# Altium schematic sheet 03-MCU.SchDoc
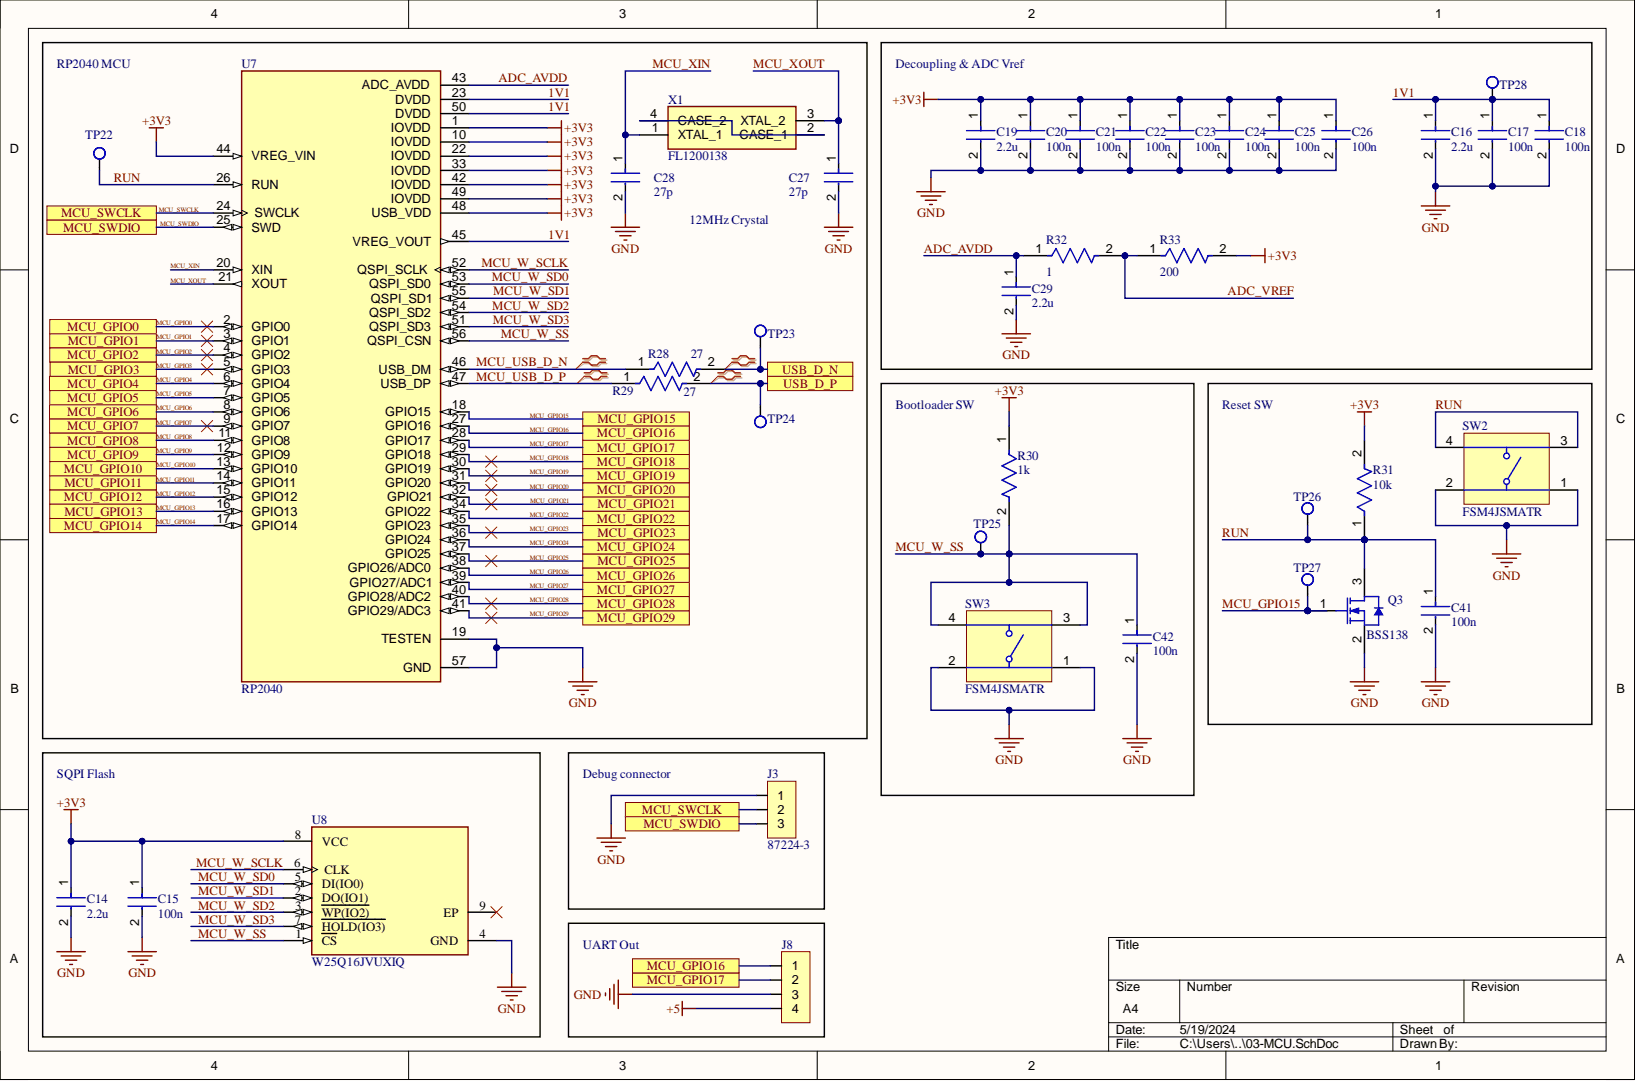
[diagram p0: 03-MCU.SchDoc]

## Parts
C14  2.2u  [FP-CC0805-0_85-MFG]
C15  100n  [FP-CC0805-0_85-MFG]
C16  2.2u  [FP-CC0805-0_85-MFG]
C17  100n  [FP-CC0805-0_85-MFG]
C18  100n  [FP-CC0805-0_85-MFG]
C19  2.2u  [FP-CC0805-0_85-MFG]
C20  100n  [FP-CC0805-0_85-MFG]
C21  100n  [FP-CC0805-0_85-MFG]
C22  100n  [FP-CC0805-0_85-MFG]
C23  100n  [FP-CC0805-0_85-MFG]
C24  100n  [FP-CC0805-0_85-MFG]
C25  100n  [FP-CC0805-0_85-MFG]
C26  100n  [FP-CC0805-0_85-MFG]
C27  27p  [FP-CC0805-0_85-MFG]
C28  27p  [FP-CC0805-0_85-MFG]
C29  2.2u  [FP-CC0805-0_85-MFG]
C41  100n  [FP-CC0805-0_85-MFG]
C42  100n  [FP-CC0805-0_85-MFG]
J3  87224-3  [FP-87224-3-MFG]
J8  TSW-104-08-L-S  [SMTC-TSW-104-08-X-S]  (value not drawn)
Q3  BSS138  [FAIR-SOT23-3_V]
R28  27  [FP-RT0805-MFG]
R29  27  [FP-RT0805-MFG]
R30  1k  [FP-RT0805-MFG]
R31  10k  [FP-RT0805-MFG]
R32  1  [FP-RT0805-MFG]
R33  200  [FP-RT0805-MFG]
SW2  FSM4JSMATR  [TECO-FSM4JSMATR_V]
SW3  FSM4JSMATR  [TECO-FSM4JSMATR_V]
TP22  Test point  [Test point-Footprint-1]  (value not drawn)
TP23  Test point  [Test point-Footprint-1]  (value not drawn)
TP24  Test point  [Test point-Footprint-1]  (value not drawn)
TP25  Test point  [Test point-Footprint-1]  (value not drawn)
TP26  Test point  [Test point-Footprint-1]  (value not drawn)
TP27  Test point  [Test point-Footprint-1]  (value not drawn)
TP28  Test point  [Test point-Footprint-1]  (value not drawn)
U7  RP2040  [QFN40P700X700X90-57N]
U8  W25Q16JVUXIQ  [FP-UX-MFG]
X1  FL1200138  [FL1200138]

## Ports
MCU_GPIO0, MCU_GPIO1, MCU_GPIO2, MCU_GPIO3, MCU_GPIO4, MCU_GPIO5, MCU_GPIO6, MCU_GPIO7, MCU_GPIO8, MCU_GPIO9, MCU_GPIO10, MCU_GPIO11, MCU_GPIO12, MCU_GPIO13, MCU_GPIO14, MCU_GPIO15, MCU_GPIO16, MCU_GPIO17, MCU_GPIO18, MCU_GPIO19, MCU_GPIO20, MCU_GPIO21, MCU_GPIO22, MCU_GPIO23, MCU_GPIO24, MCU_GPIO25, MCU_GPIO26, MCU_GPIO27, MCU_GPIO28, MCU_GPIO29, MCU_SWCLK, MCU_SWDIO, USB_D_N, USB_D_P

## Nets
+3V3: C14.1 C15.1 C19.1 C20.1 C21.1 C22.1 C23.1 C24.1 C25.1 C26.1 R30.1 R31.2 R33.2 U7.1(IOVDD) U7.10(IOVDD) U7.22(IOVDD) U7.33(IOVDD) U7.42(IOVDD) U7.44(VREG_VIN) U7.48(USB_VDD) U7.49(IOVDD) U8.8(VCC)
+5: J8.4
GND: C14.2 C15.2 C16.2 C17.2 C18.2 C19.2 C20.2 C21.2 C22.2 C23.2 C24.2 C25.2 C26.2 C27.2 C28.2 C29.2 C41.2 C42.2 J3.1 J8.3 Q3.2 SW2.1 SW2.2 SW3.1 SW3.2 U7.19(TESTEN) U7.57(GND) U8.4(GND)
1V1: C16.1 C17.1 C18.1 TP28.1 U7.23(DVDD) U7.45(VREG_VOUT) U7.50(DVDD)
ADC_AVDD: C29.1 R32.1 U7.43(ADC_AVDD)
ADC_VREF: R32.2 R33.1
MCU_GPIO0: U7.2(GPIO0) ; port MCU_GPIO0
MCU_GPIO1: U7.3(GPIO1) ; port MCU_GPIO1
MCU_GPIO2: U7.4(GPIO2) ; port MCU_GPIO2
MCU_GPIO3: U7.5(GPIO3) ; port MCU_GPIO3
MCU_GPIO4: U7.6(GPIO4) ; port MCU_GPIO4
MCU_GPIO5: U7.7(GPIO5) ; port MCU_GPIO5
MCU_GPIO6: U7.8(GPIO6) ; port MCU_GPIO6
MCU_GPIO7: U7.9(GPIO7) ; port MCU_GPIO7
MCU_GPIO8: U7.11(GPIO8) ; port MCU_GPIO8
MCU_GPIO9: U7.12(GPIO9) ; port MCU_GPIO9
MCU_GPIO10: U7.13(GPIO10) ; port MCU_GPIO10
MCU_GPIO11: U7.14(GPIO11) ; port MCU_GPIO11
MCU_GPIO12: U7.15(GPIO12) ; port MCU_GPIO12
MCU_GPIO13: U7.16(GPIO13) ; port MCU_GPIO13
MCU_GPIO14: U7.17(GPIO14) ; port MCU_GPIO14
MCU_GPIO15: Q3.1 TP27.1 U7.18(GPIO15) ; port MCU_GPIO15
MCU_GPIO16: J8.1 U7.27(GPIO16) ; port MCU_GPIO16
MCU_GPIO17: J8.2 U7.28(GPIO17) ; port MCU_GPIO17
MCU_GPIO18: U7.29(GPIO18) ; port MCU_GPIO18
MCU_GPIO19: U7.30(GPIO19) ; port MCU_GPIO19
MCU_GPIO20: U7.31(GPIO20) ; port MCU_GPIO20
MCU_GPIO21: U7.32(GPIO21) ; port MCU_GPIO21
MCU_GPIO22: U7.34(GPIO22) ; port MCU_GPIO22
MCU_GPIO23: U7.35(GPIO23) ; port MCU_GPIO23
MCU_GPIO24: U7.36(GPIO24) ; port MCU_GPIO24
MCU_GPIO25: U7.37(GPIO25) ; port MCU_GPIO25
MCU_GPIO26: U7.38(GPIO26/ADC0) ; port MCU_GPIO26
MCU_GPIO27: U7.39(GPIO27/ADC1) ; port MCU_GPIO27
MCU_GPIO28: U7.40(GPIO28/ADC2) ; port MCU_GPIO28
MCU_GPIO29: U7.41(GPIO29/ADC3) ; port MCU_GPIO29
MCU_SWCLK: J3.2 U7.24(SWCLK) ; port MCU_SWCLK
MCU_SWDIO: J3.3 U7.25(SWD) ; port MCU_SWDIO
MCU_USB_D_N: R28.1 U7.46(USB_DM)
MCU_USB_D_P: R29.1 U7.47(USB_DP)
MCU_W_SCLK: U7.52(QSPI_SCLK) U8.6(CLK)
MCU_W_SD0: U7.53(QSPI_SD0) U8.5(DI(IO0))
MCU_W_SD1: U7.55(QSPI_SD1) U8.2(DO(IO1))
MCU_W_SD2: U7.54(QSPI_SD2) U8.3(WP(IO2))
MCU_W_SD3: U7.51(QSPI_SD3) U8.7(HOLD(IO3))
MCU_W_SS: C42.1 R30.2 SW3.3 SW3.4 TP25.1 U7.56(QSPI_CSN) U8.1(CS)
MCU_XIN: C28.1 U7.20(XIN) X1.1(XTAL_1)
MCU_XOUT: C27.1 U7.21(XOUT) X1.3(XTAL_2)
RUN: C41.1 Q3.3 R31.1 SW2.3 SW2.4 TP22.1 TP26.1 U7.26(RUN)
USB_D_N: R28.2 TP23.1 ; port USB_D_N
USB_D_P: R29.2 TP24.1 ; port USB_D_P
NetX1_2: X1.2(CASE_1) X1.4(CASE_2)

Not connected: U8 9(EP)

## Library data of the parts
C14 C15 C17 C18 C20-C29 C41 C42 = CMP-1036-04410-2: CAP CER 0.1UF 25V X7R 0805; Manufacturer: Yageo; Manufacturer Part Number: CC0805KRX7R8BB104; Capacitance: 100 nF; Material: Ceramic; Tolerance: 0.1; Value: 100 nF; Automotive: No; Category: Cap; Component Kind: Standard; ComponentLink2Description: Datasheet; ComponentLink2URL: http://www.yageo.com/documents/recent/UPY-GPHC_Y5V_6.3V-to-50V_8.pdf; Device Class L1: Passive Components; Device Class L2: Capacitors; Device Class L3: Ceramic Capacitors; Digikey Description: CAP CER 0.1UF 25V X7R 0805; Footprint Url: http://www.yageo.com/exep/pages/download/literatures/UPY-C_GEN_24.pdf; Height: 0.95mm; Ipc Land Pattern Name: CAPC200125X85; Lead Free: Yes; Library Name: Altium Content Vault; Mouser Description: Multilayer Ceramic Capacitors MLCC - SMD/SMT 100nF 25V X7R 10%; Octopart: https://octopart.com/cc0805krx7r8bb104-yageo-39467766; Package: CC0805-0.85; RoHS: TRUE; Temperature: -30°C to +85°C; Temperature Characteristic: X7R; Temperature Range High: +85°C; Temperature Range Low: -30°C; Voltage Rating: 25 V
  C14 C29: LCSC_Part_#: C377773
  C15 C17 C18 C20-C26 C41 C42: LCSC_Part_#: C49678
  C27 C28: LCSC_Part_#: C1808
C16 C19 = CMP-1036-04410-2: CAP CER 2.2UF 25V X7R 0805; Manufacturer: Yageo; Manufacturer Part Number: CC0805KRX7R8BB104; Capacitance: 100 nF; Material: Ceramic; Tolerance: 0.1; Value: 100 nF; Automotive: No; Category: Cap; Component Kind: Standard; ComponentLink2Description: Datasheet; ComponentLink2URL: http://www.yageo.com/documents/recent/UPY-GPHC_Y5V_6.3V-to-50V_8.pdf; Device Class L1: Passive Components; Device Class L2: Capacitors; Device Class L3: Ceramic Capacitors; Digikey Description: CAP CER 0.1UF 25V X7R 0805; Footprint Url: http://www.yageo.com/exep/pages/download/literatures/UPY-C_GEN_24.pdf; Height: 0.95mm; Ipc Land Pattern Name: CAPC200125X85; LCSC_Part_#: C377773; Lead Free: Yes; Library Name: Altium Content Vault; Mouser Description: Multilayer Ceramic Capacitors MLCC - SMD/SMT 100nF 25V X7R 10%; Octopart: https://octopart.com/cc0805krx7r8bb104-yageo-39467766; Package: CC0805-0.85; RoHS: TRUE; Temperature: -30°C to +85°C; Temperature Characteristic: X7R; Temperature Range High: +85°C; Temperature Range Low: -30°C; Voltage Rating: 25 V
J3 = CMP-002-00074-1: Board Connector, 3 Contact(s), 1 Row(s), Male, Straight, 0.1 inch Pitch, Solder Terminal, Black Insulator, Receptacle; Component Kind: Standard; Connector Type: BOARD CONNECTOR; Max Operating Temperature: 105°C; Min Operating Temperature: -65°C; Mounting Technology: Board; RoHS Compliant: Yes; Library Name: Clement Verda
J8 = CMP-002-00076-1: Board Connector, 4 Contact(s), 1 Row(s), Male, Straight, Solder Terminal; Component Kind: Standard; Connector Type: BOARD CONNECTOR; Mounting Technology: Board; RoHS Compliant: Yes; Library Name: Clement Verda
Q3 = CMP-013-00021-4: N-Channel Logic Level Enhancement Mode Field Effect Transistor, 220 mA, 50 V, -55 to 150 degC, 3-Pin SOT-23, RoHS, Tape and Reel; Manufacturer: Fairchild; Elements: 1; Input Capacitance: 27pF; Nominal Dual Supply Voltage: 50V; Nominal Vgs: 1.3V; Package Version: Rev. 12, 03/2016; Power: 360mW; Rds On Max: 3.5Ohm; Resistance: 0.7Ohm; Typical Rise Time: 9ns; Case/Package: TO-236-3; Component Kind: Standard; Datasheet URL: https://www.fairchildsemi.com/datasheets/BS/BSS138.pdf; Datasheet Version: Rev. C, 10/2005; LCSC_Part_#: C112239; Max Operating Temperature: 150°C; Min Operating Temperature: -55°C; Mounting Technology: SM; Package Description: 3-Pin Small Outline Transistor, Body 2.92 x 1.325 mm, Pitch 0.95 mm; Package Quantity: 1; Package Reference: SOT23-3; Packaging: TapeandReel; Pins: 3; RoHS Compliant: True; Library Name: Clement Verda
R28 R29 R31-R33 = CMP-03412-005354-1: RES SMD 4.7K OHM 0.5% 1/8W 0805; Manufacturer: Yageo; Manufacturer Part Number: RT0805DRE074K7L; Power Rating: 0.125W; Resistance: 4.7KΩ; Temperature Coefficient: 50 ppm/°C; Tolerance: 0.01; Value: 4.7KΩ; Voltage Rating: 150V; Automotive: No; Category: Res; Component Kind: Standard; ComponentLink2Description: Datasheet; ComponentLink2URL: http://www.yageo.com/documents/recent/PYu-RT_1-to-0.01_RoHS_L_9.pdf; Composition: Thin Film; Device Class L1: Passive Components; Device Class L2: Resistors; Device Class L3: Chip SMD Resistors; Digikey Description: RES SMD 4.7K OHM 0.5% 1/8W 0805; Footprint Url: http://www.yageo.com/exep/pages/download/literatures/PYu-R_Mount_10.pdf; Height: 0.6mm; Ipc Land Pattern Name: RESC200125X50; Lead Free: Yes; Library Name: Altium Content Vault; Octopart: https://octopart.com/rt0805dre074k7l-yageo-1242027; Package: RT0805; RoHS: TRUE; Series: RT; Temperature: -55°C to +155°C; Temperature Range High: +155°C; Temperature Range Low: -55°C
  R28 R29: LCSC_Part_#: C17594
  R31: LCSC_Part_#: C17414
  R32: LCSC_Part_#: C25271
  R33: LCSC_Part_#: C17540
R30 = CMP-03412-005354-1: RES SMD 1K OHM 1% 1/8W 0805; Manufacturer: Yageo; Manufacturer Part Number: RT0805DRE074K7L; Power Rating: 0.125W; Resistance: 4.7KΩ; Temperature Coefficient: 50 ppm/°C; Tolerance: 0.01; Value: 4.7KΩ; Voltage Rating: 150V; Automotive: No; Category: Res; Component Kind: Standard; ComponentLink2Description: Datasheet; ComponentLink2URL: http://www.yageo.com/documents/recent/PYu-RT_1-to-0.01_RoHS_L_9.pdf; Composition: Thin Film; Device Class L1: Passive Components; Device Class L2: Resistors; Device Class L3: Chip SMD Resistors; Digikey Description: RES SMD 4.7K OHM 0.5% 1/8W 0805; Footprint Url: http://www.yageo.com/exep/pages/download/literatures/PYu-R_Mount_10.pdf; Height: 0.6mm; Ipc Land Pattern Name: RESC200125X50; Lead Free: Yes; Library Name: Altium Content Vault; Octopart: https://octopart.com/rt0805dre074k7l-yageo-1242027; Package: RT0805; RoHS: TRUE; Series: RT; Temperature: -55°C to +155°C; Temperature Range High: +155°C; Temperature Range Low: -55°C
SW2 SW3 = CMP-2000-07486-1: Micro Miniature Push Button Switch FSM JSM, 50 mA, -35 to 85 degC, 4-Pin SMD, RoHS, Tape and Reel; Manufacturer: TE Connectivity; Actuator Length: 0.055inch; Actuator Material: Polyamide; Capacitance: 0f; Case\/Package: SMD/SMT; Contact Current Rating: 50mA; Contact Resistance: 100mOhm; Electrical Life: 100000Cycles; Illumination Type: Illuminated; Max Voltage Rating (DC): 12V; Operating Force: 160gf; Switch Travel: 0.25mm; Throw Configuration: SPST; Voltage Rating (DC): 24V; Component Kind: Standard; Contact Material: CopperAlloy; Contact Plating: Silver; Current Rating: 50mA; Datasheet URL: http://www.te.com/commerce/DocumentDelivery/DDEController?Action=showdoc&DocId=Customer+Drawing%7F2-1437565-7%7FV%7Fpdf%7FEnglish%7FENG_CD_2-1437565-7_V.pdf%7F4-1437565-2; Datasheet Version: Rev. V, 02/2015; Library Name: Altium Content Vault; Manufacturer URL: http://www.te.com/; Max Operating Temperature: 85degC; Mechanical Life: 100000Cycles; Min Operating Temperature: -35degC; Mount: SurfaceMount; Mounting Technology: SurfaceMount; Orientation: Straight; Package Description: 4-Pin Surface Mount Device, Body 6 x 6 mm, Pitch 4.5 mm; Package Quantity: 1200; Package Reference: FSM4JSMATR; Packaging: TapeandReel; REACH SVHC: Unknown; RoHSCompliant: true; Termination: GullWing
TP22-TP28 = CMP-002-00075-1: Component Kind: Standard; Description: test point; Library Name: Clement Verda
U7 = CMP-003-000000-2: RP2040 chip for Raspberry Pi Pico - ARMÂ® CortexÂ®-M0+ MCU 32-Bit; Availability: In Stock; Check_prices: https://www.snapeda.com/parts/RP2040/Raspberry+Pi/view-part/?ref=eda; Component Kind: Standard; Component Type: Standard; Design Item ID: RP2040; LCSC_Part_#: C2040; MF: Raspberry Pi; MP: RP2040; Package: None; Pin Count: 57; Price: None; Purchase-URL: https://pricing.snapeda.com/search/part/RP2040/?ref=eda; SnapEDA_Link: https://www.snapeda.com/parts/RP2040/Raspberry+Pi/view-part/?ref=snap; Source: RP2040.SchLib; Library Name: Clement Verda
U8 = CMP-78876-000011-1: IC FLASH 16MBIT SPI/QUAD 8USON; Component Kind: Standard; Library Name: Altium Content Vault; RoHS Compliant: Yes
X1 = CMP-003-00030-1: 12 MHz +/-15ppm Crystal 8pF 120 Ohms 4-SMD, No Lead; Manufacturer_Name: Diodes Inc.; Geometry.Height: 0.78mm; Component Kind: Standard; Datasheet Link: https://www.diodes.com/assets/Datasheets/FL.pdf; Design Item ID: FL1200138; LCSC_Part_#: C526612; Manufacturer_Part_Number: FL1200138; Source: FL1200138.SchLib; Library Name: Clement Verda
